# Altium schematic sheet InductorLadder.SchDoc
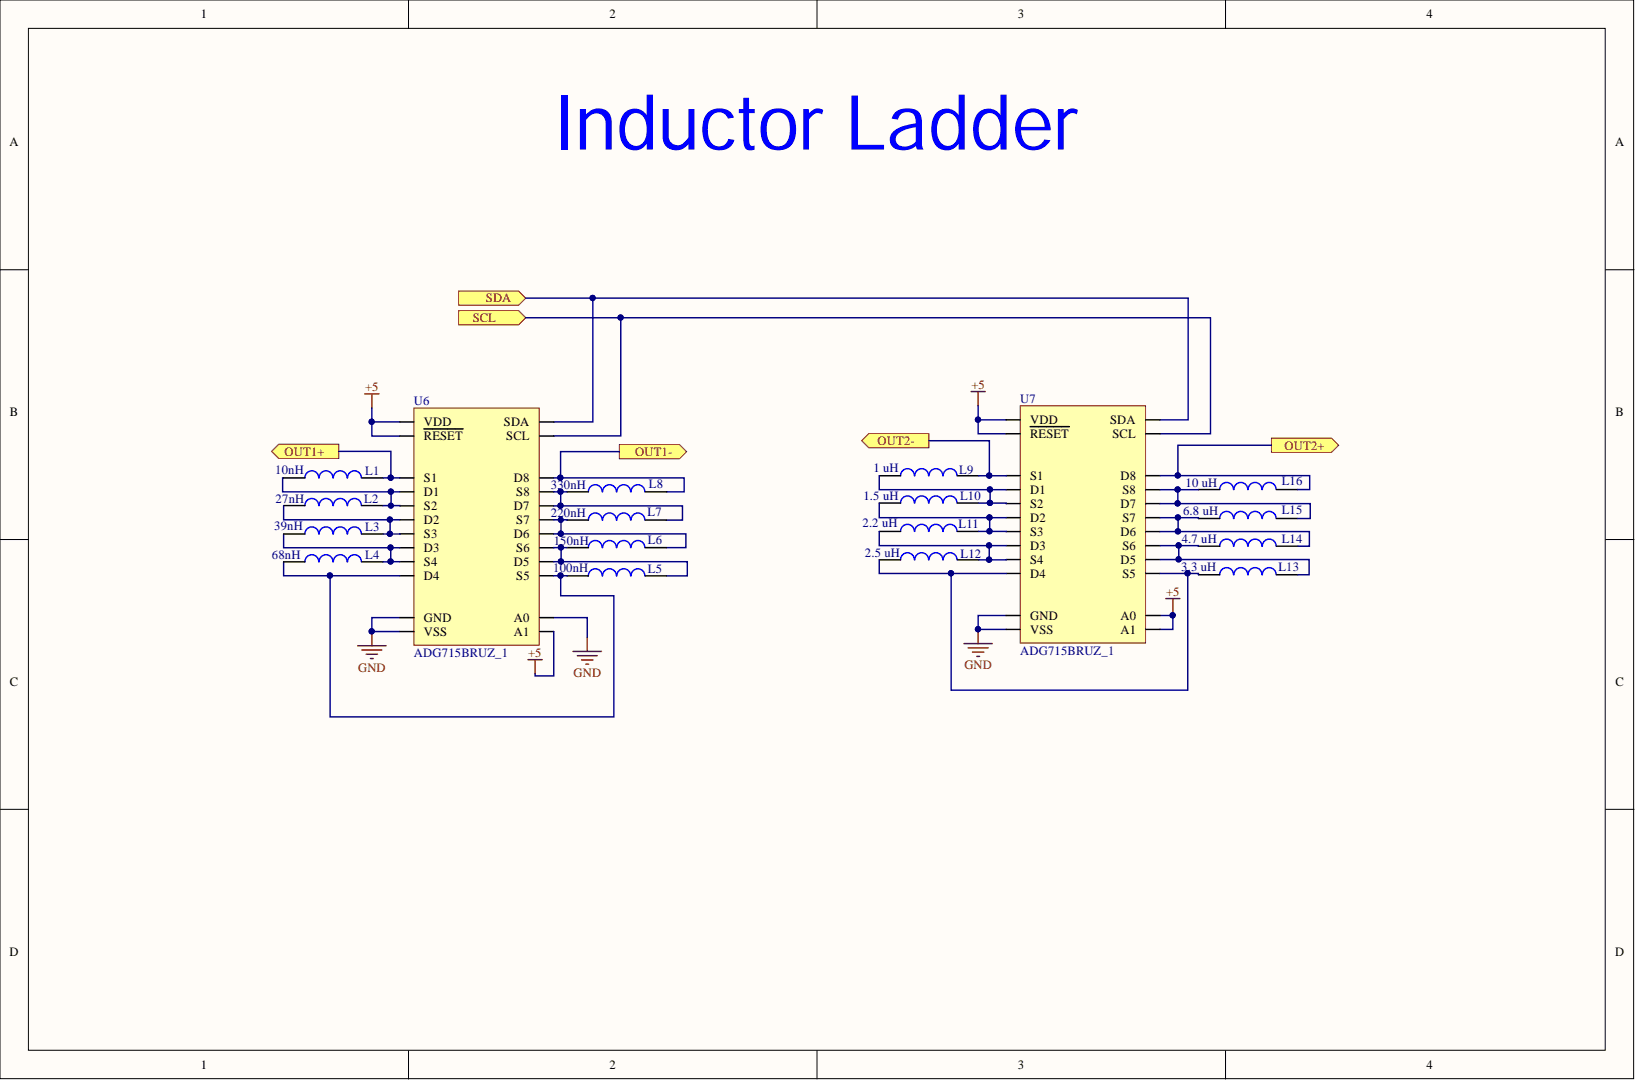
[diagram p0: InductorLadder.SchDoc]

## Parts
L1  value 10nH  [WE-KI_1008A]  (hidden comment: WE-KI, 744762110A)
L2  value 27nH  [WE-KI_1008A]  (hidden comment: WE-KI, 744762127A)
L3  value 39nH  [WE-KI_1008A]  (hidden comment: WE-KI, 744762139A)
L4  value 68nH  [WE-KI_1008A]  (hidden comment: WE-KI, 744762168A)
L5  value 100nH  [WE-KI_1008A]  (hidden comment: WE-KI, 744762210A)
L6  value 150nH  [WE-KI_1008A]  (hidden comment: WE-KI, 744762215A)
L7  value 220nH  [WE-KI_1008A]  (hidden comment: WE-KI, 744762222A)
L8  value 330nH  [WE-KI_1008A]  (hidden comment: WE-KI, 744762233A)
L9  1 uH  [WE-LQS_2010]
L10  1.5 uH  [WE-LQS_2010]
L11  2.2 uH  [WE-LQS_2010]
L12  2.5 uH  [WE-LQS_2010]
L13  3.3 uH  [WE-LQS_2010]
L14  4.7 uH  [WE-LQS_2010]
L15  6.8 uH  [WE-LQS_2010]
L16  10 uH  [WE-LQS_2010]
U6  ADG715BRUZ_1  [ADG715BRUZ]
U7  ADG715BRUZ_1  [ADG715BRUZ]

## Ports
OUT1+, OUT1-, OUT2+, OUT2-, SCL, SDA

## Nets
+5: U6.2(VDD) U6.22(A1) U6.23(RESET) U7.2(VDD) U7.22(A1) U7.23(RESET) U7.24(A0)
GND: U6.4(GND) U6.21(VSS) U6.24(A0) U7.4(GND) U7.21(VSS)
SCL: U6.1(SCL) U7.1(SCL) ; port SCL
SDA: U6.3(SDA) U7.3(SDA) ; port SDA
NetL1_1: L1.1 L2.2 U6.6(D1) U6.7(S2)
NetL1_2: L1.2 U6.5(S1)
NetL2_1: L2.1 L3.2 U6.8(D2) U6.9(S3)
NetL3_1: L3.1 L4.2 U6.10(D3) U6.11(S4)
NetL4_1: L4.1 L5.1 U6.12(D4) U6.14(S5)
NetL5_2: L5.2 L6.1 U6.13(D5) U6.16(S6)
NetL6_2: L6.2 L7.1 U6.15(D6) U6.18(S7)
NetL7_2: L7.2 L8.1 U6.17(D7) U6.20(S8)
NetL8_2: L8.2 U6.19(D8)
NetL9_1: L9.1 L10.2 U7.6(D1) U7.7(S2)
NetL9_2: L9.2 U7.5(S1)
NetL10_1: L10.1 L11.2 U7.8(D2) U7.9(S3)
NetL11_1: L11.1 L12.2 U7.10(D3) U7.11(S4)
NetL12_1: L12.1 L13.1 U7.12(D4) U7.14(S5)
NetL13_2: L13.2 L14.1 U7.13(D5) U7.16(S6)
NetL14_2: L14.2 L15.1 U7.15(D6) U7.18(S7)
NetL15_2: L15.2 L16.1 U7.17(D7) U7.20(S8)
NetL16_2: L16.2 U7.19(D8)

## Library data of the parts
L1 = WE-KI, 744762110A: WE-KI SMD Wire Wound Ceramic Inductor, size 1008A, 10nH; Component Kind: Standard; ComponentLink1Description: Manufacturer; ComponentLink1URL: http://www.we-online.de; ComponentLink2Description: Datasheet; ComponentLink2URL: http://katalog.we-online.de/pbs/datasheet/744762110A.pdf; PartNumber: 744762110A; PublishedDate: Sep. 2016; Size: 1008A; Supplier 1: Digi-Key; Supplier Part Number 1: 732-1808-6-ND; Library Name: DynamicComplexModulo_v1i1.SchLib; Publisher: Wurth Elektronik
L2 = WE-KI, 744762127A: WE-KI SMD Wire Wound Ceramic Inductor, size 1008A, 27nH; Component Kind: Standard; ComponentLink1Description: Manufacturer; ComponentLink1URL: http://www.we-online.de; ComponentLink2Description: Datasheet; ComponentLink2URL: http://katalog.we-online.de/pbs/datasheet/744762127A.pdf; PartNumber: 744762127A; PublishedDate: Sep. 2016; Size: 1008A; Supplier 1: Digi-Key; Supplier Part Number 1: 732-1813-6-ND; Library Name: DynamicComplexModulo_v1i1.SchLib; Publisher: Wurth Elektronik
L3 = WE-KI, 744762139A: WE-KI SMD Wire Wound Ceramic Inductor, size 1008A, 39nH; Component Kind: Standard; ComponentLink1Description: Manufacturer; ComponentLink1URL: http://www.we-online.de; ComponentLink2Description: Datasheet; ComponentLink2URL: http://katalog.we-online.de/pbs/datasheet/744762139A.pdf; PartNumber: 744762139A; PublishedDate: Sep. 2016; Size: 1008A; Supplier 1: Digi-Key; Supplier Part Number 1: 732-1815-6-ND; Library Name: DynamicComplexModulo_v1i1.SchLib; Publisher: Wurth Elektronik
L4 = WE-KI, 744762168A: WE-KI SMD Wire Wound Ceramic Inductor, size 1008A, 68nH; Component Kind: Standard; ComponentLink1Description: Manufacturer; ComponentLink1URL: http://www.we-online.de; ComponentLink2Description: Datasheet; ComponentLink2URL: http://katalog.we-online.de/pbs/datasheet/744762168A.pdf; PartNumber: 744762168A; PublishedDate: Sep. 2016; Size: 1008A; Supplier 1: Digi-Key; Supplier Part Number 1: 732-1818-6-ND; Library Name: DynamicComplexModulo_v1i1.SchLib; Publisher: Wurth Elektronik
L5 = WE-KI, 744762210A: WE-KI SMD Wire Wound Ceramic Inductor, size 1008A, 100nH; Component Kind: Standard; ComponentLink1Description: Manufacturer; ComponentLink1URL: http://www.we-online.de; ComponentLink2Description: Datasheet; ComponentLink2URL: http://katalog.we-online.de/pbs/datasheet/744762210A.pdf; PartNumber: 744762210A; PublishedDate: Sep. 2016; Size: 1008A; Supplier 1: Digi-Key; Supplier Part Number 1: 732-1820-6-ND; Library Name: DynamicComplexModulo_v1i1.SchLib; Publisher: Wurth Elektronik
L6 = WE-KI, 744762215A: WE-KI SMD Wire Wound Ceramic Inductor, size 1008A, 150nH; Component Kind: Standard; ComponentLink1Description: Manufacturer; ComponentLink1URL: http://www.we-online.de; ComponentLink2Description: Datasheet; ComponentLink2URL: http://katalog.we-online.de/pbs/datasheet/744762215A.pdf; PartNumber: 744762215A; PublishedDate: Sep. 2016; Size: 1008A; Supplier 1: Digi-Key; Supplier Part Number 1: 732-1822-6-ND; Library Name: DynamicComplexModulo_v1i1.SchLib; Publisher: Wurth Elektronik
L7 = WE-KI, 744762222A: WE-KI SMD Wire Wound Ceramic Inductor, size 1008A, 220nH; Component Kind: Standard; ComponentLink1Description: Manufacturer; ComponentLink1URL: http://www.we-online.de; ComponentLink2Description: Datasheet; ComponentLink2URL: http://katalog.we-online.de/pbs/datasheet/744762222A.pdf; PartNumber: 744762222A; PublishedDate: Sep. 2016; Size: 1008A; Supplier 1: Digi-Key; Supplier Part Number 1: 732-1824-6-ND; Library Name: DynamicComplexModulo_v1i1.SchLib; Publisher: Wurth Elektronik
L8 = WE-KI, 744762233A: WE-KI SMD Wire Wound Ceramic Inductor, size 1008A, 330nH; Component Kind: Standard; ComponentLink1Description: Manufacturer; ComponentLink1URL: http://www.we-online.de; ComponentLink2Description: Datasheet; ComponentLink2URL: http://katalog.we-online.de/pbs/datasheet/744762233A.pdf; PartNumber: 744762233A; PublishedDate: Sep. 2016; Size: 1008A; Supplier 1: Digi-Key; Supplier Part Number 1: 732-1826-6-ND; Library Name: DynamicComplexModulo_v1i1.SchLib; Publisher: Wurth Elektronik
L9 = 74404020010: WE-LQS SMD Power Inductor, size 2010, 1 uH, 1.49 A; Manufacturer: Wurth Electronics; DC Resistance: 0.095 Ohm; Rated Current max.: 1.49 A; Component Kind: Standard; ComponentLink1Description: Manufacturer; ComponentLink1URL: http://www.we-online.de; ComponentLink2Description: Datasheet; ComponentLink2URL: http://katalog.we-online.de/pbs/datasheet/74404020010.pdf; Inductance: 1 uH; Manufacturer Part Number: 74404020010; Operating Temperature: -40 to +125 degC; Part Number: 74404020010; Pricing 1: 1=0.56, 50=0.4698, 100=0.357, 1000=0.31007 (USD); Published Date: Dec. 2017; Size: 2010; Stock 1: 3959; Supplier 1: Digi-Key; Supplier Part Number 1: 732-10739-6-ND; Library Name: DynamicComplexModulo_v1i1.SchLib; Publisher: Wurth Elektronik
L10 = 74404020015: WE-LQS SMD Power Inductor, size 2010, 1.5 uH, 1.19 A; Manufacturer: Wurth Electronics; DC Resistance: 0.145 Ohm; Rated Current max.: 1.19 A; Component Kind: Standard; ComponentLink1Description: Manufacturer; ComponentLink1URL: http://www.we-online.de; ComponentLink2Description: Datasheet; ComponentLink2URL: http://katalog.we-online.de/pbs/datasheet/74404020015.pdf; Inductance: 1.5 uH; Manufacturer Part Number: 74404020015; Operating Temperature: -40 to +125 degC; Part Number: 74404020015; Pricing 1: 1=0.56, 50=0.4698, 100=0.357, 1000=0.31007 (USD); Published Date: Dec. 2017; Size: 2010; Stock 1: 3931; Supplier 1: Digi-Key; Supplier Part Number 1: 732-10740-6-ND; Library Name: DynamicComplexModulo_v1i1.SchLib; Publisher: Wurth Elektronik
L11 = 74404020022: WE-LQS SMD Power Inductor, size 2010, 2.2 uH, 0.96 A; Manufacturer: Wurth Electronics; DC Resistance: 0.22 Ohm; Rated Current max.: 0.96 A; Component Kind: Standard; ComponentLink1Description: Manufacturer; ComponentLink1URL: http://www.we-online.de; ComponentLink2Description: Datasheet; ComponentLink2URL: http://katalog.we-online.de/pbs/datasheet/74404020022.pdf; Inductance: 2.2 uH; Manufacturer Part Number: 74404020022; Operating Temperature: -40 to +125 degC; Part Number: 74404020022; Pricing 1: 1=0.56, 50=0.4698, 100=0.357, 1000=0.31007 (USD); Published Date: Dec. 2017; Size: 2010; Stock 1: 3236; Supplier 1: Digi-Key; Supplier Part Number 1: 732-10741-6-ND; Library Name: DynamicComplexModulo_v1i1.SchLib; Publisher: Wurth Elektronik
L12 = 74405020022: WE-LQS SMD Power Inductor, size 2010, 2.5 uH, 0.96 A; Manufacturer: Wurth Electronics; Manufacturer Part Number: 74404020022; DC Resistance: 0.22 Ohm; Inductance: 2.2 uH; Rated Current max.: 0.96 A; Component Kind: Standard; ComponentLink1Description: Manufacturer; ComponentLink1URL: http://www.we-online.de; ComponentLink2Description: Datasheet; ComponentLink2URL: http://katalog.we-online.de/pbs/datasheet/74404020022.pdf; Operating Temperature: -40 to +125 degC; Part Number: 74404020022; Pricing 1: 1=0.63, 50=0.522, 100=0.3967, 1000=0.34451 (USD); Published Date: Dec. 2017; Size: 2010; Stock 1: 4000; Supplier 1: Digi-Key; Supplier Part Number 1: 732-10770-6-ND; Library Name: DynamicComplexModulo_v1i1.SchLib; Publisher: Wurth Elektronik
L13 = 74404020033: WE-LQS SMD Power Inductor, size 2010, 3.3 uH, 0.87 A; Manufacturer: Wurth Electronics; DC Resistance: 0.279 Ohm; Rated Current max.: 0.87 A; Component Kind: Standard; ComponentLink1Description: Manufacturer; ComponentLink1URL: http://www.we-online.de; ComponentLink2Description: Datasheet; ComponentLink2URL: http://katalog.we-online.de/pbs/datasheet/74404020033.pdf; Inductance: 3.3 uH; Manufacturer Part Number: 74404020033; Operating Temperature: -40 to +125 degC; Part Number: 74404020033; Pricing 1: 1=0.56, 50=0.4698, 100=0.357, 1000=0.31007 (USD); Published Date: Dec. 2017; Size: 2010; Stock 1: 3787; Supplier 1: Digi-Key; Supplier Part Number 1: 732-10742-6-ND; Library Name: DynamicComplexModulo_v1i1.SchLib; Publisher: Wurth Elektronik
L14 = 74404020047: WE-LQS SMD Power Inductor, size 2010, 4.7 uH, 0.73 A; Manufacturer: Wurth Electronics; DC Resistance: 0.399 Ohm; Rated Current max.: 0.73 A; Component Kind: Standard; ComponentLink1Description: Manufacturer; ComponentLink1URL: http://www.we-online.de; ComponentLink2Description: Datasheet; ComponentLink2URL: http://katalog.we-online.de/pbs/datasheet/74404020047.pdf; Inductance: 4.7 uH; Manufacturer Part Number: 74404020047; Operating Temperature: -40 to +125 degC; Part Number: 74404020047; Pricing 1: 1=0.56, 50=0.4698, 100=0.357, 1000=0.31007 (USD); Published Date: Dec. 2017; Size: 2010; Stock 1: 3398; Supplier 1: Digi-Key; Supplier Part Number 1: 732-10743-6-ND; Library Name: DynamicComplexModulo_v1i1.SchLib; Publisher: Wurth Elektronik
L15 = 74404020068: WE-LQS SMD Power Inductor, size 2010, 6.8 uH, 0.56 A; Manufacturer: Wurth Electronics; DC Resistance: 0.68 Ohm; Rated Current max.: 0.56 A; Component Kind: Standard; ComponentLink1Description: Manufacturer; ComponentLink1URL: http://www.we-online.de; ComponentLink2Description: Datasheet; ComponentLink2URL: http://katalog.we-online.de/pbs/datasheet/74404020068.pdf; Inductance: 6.8 uH; Manufacturer Part Number: 74404020068; Operating Temperature: -40 to +125 degC; Part Number: 74404020068; Pricing 1: 1=0.56, 50=0.4698, 100=0.357, 1000=0.31007 (USD); Published Date: Dec. 2017; Size: 2010; Stock 1: 3558; Supplier 1: Digi-Key; Supplier Part Number 1: 732-10744-6-ND; Library Name: DynamicComplexModulo_v1i1.SchLib; Publisher: Wurth Elektronik
L16 = 74404020100: WE-LQS SMD Power Inductor, size 2010, 10 uH, 0.5 A; Manufacturer: Wurth Electronics; DC Resistance: 0.85 Ohm; Rated Current max.: 0.5 A; Component Kind: Standard; ComponentLink1Description: Manufacturer; ComponentLink1URL: http://www.we-online.de; ComponentLink2Description: Datasheet; ComponentLink2URL: http://katalog.we-online.de/pbs/datasheet/74404020100.pdf; ComponentLink3Description: http://www.farnell.com/datasheets/2277209.pdf; ComponentLink3URL: http://www.farnell.com/datasheets/2277209.pdf; Inductance: 10 uH; Manufacturer Part Number: 74404020100; Operating Temperature: -40 to +125 degC; Part Number: 74404020100; Pricing 1: 1=0.56, 50=0.4698, 100=0.357, 1000=0.31007 (USD); Published Date: Dec. 2017; Size: 2010; Stock 1: 3185; Supplier 1: Digi-Key; Supplier Part Number 1: 732-10745-6-ND; Library Name: DynamicComplexModulo_v1i1.SchLib; Publisher: Wurth Elektronik
U6 U7 = ADG715BRUZ_1: ANALOG DEVICES         ADG715BRUZ            Analogue Switch, Octal Channel, SPST, 8 Channels, 4.5 ohm, 2.7V to 5.5V, TSSOP, 24 Pins; -3db Bandwidth: 155MHz; Drain to Source Resistance: 2.5R; Dual Supply Voltage: 2.5V; High Level Output Current: 30mA; Interface: Serial; Max Dual Supply Voltage: 2.75V; Max Power Dissipation: 125uW; Max Supply Current: 20uA; Min Dual Supply Voltage: 2.25V; Number of Bits: 8; On-State Resistance: 4.5R; Operating Supply Current: 10uA; Supply Type: Single,Dual; Turn-Off Delay Time: 130ns; Turn-On Delay Time: 140ns; Bandwidth: 155MHz; Case/Package: TSSOP; Component Kind: Standard; ComponentLink1Description: https://datasheet.ciiva.com/2978/adg714-715-2978656.pdf; ComponentLink1URL: https://datasheet.ciiva.com/2978/adg714-715-2978656.pdf; ComponentLink2Description: https://datasheet.ciiva.com/1668/adg714-715-1668978.pdf; ComponentLink2URL: https://datasheet.ciiva.com/1668/adg714-715-1668978.pdf; ComponentLink3Description: https://datasheet.ciiva.com/15127/getdatasheetpartid-123058-15127194.pdf; ComponentLink3URL: https://datasheet.ciiva.com/15127/getdatasheetpartid-123058-15127194.pdf; ComponentLink4Description: https://datasheet.ciiva.com/16637/adg714-715-16637994.pdf; ComponentLink4URL: https://datasheet.ciiva.com/16637/adg714-715-16637994.pdf; ComponentLink5Description: https://datasheet.ciiva.com/5797/adg714-715-5797179.pdf; ComponentLink5URL: https://datasheet.ciiva.com/5797/adg714-715-5797179.pdf; ComponentLink6Description: https://datasheet.ciiva.com/48708/001248020-da-01-en-ic-switch-octal-adg715bruz-tssop-24-adi-48708535.pdf; ComponentLink6URL: https://datasheet.ciiva.com/48708/001248020-da-01-en-ic-switch-octal-adg715bruz-tssop-24-adi-48708535.pdf; Contact Plating: Tin,Matte; Height: 1.05mm; Lead Free: Contains Lead; Length: 7.8mm; Manufacturer: Analog Devices; Manufacturer Part Number: ADG715BRUZ; Max Operating Temperature: 85°C; Max Supply Voltage: 5.5V; Min Operating Temperature: -40°C; Min Supply Voltage: 2.7V; Nominal Supply Current: 20uA; Number of Channels: 8; Number of Circuits: 8; Number of Inputs: 8; Number of Outputs: 8; Number of Pins: 24; Package Quantity: 62; Packaging: Rail/Tube; Power Dissipation: 125uW; Pricing 1: 1=6.12, 62=5.19758, 124=4.50452, 558=3.83461, 1054=3.234 (USD); Radiation Hardening: No; REACH SVHC: No SVHC; Stock 1: 3027; Supplier 1: Digi-Key; Supplier Part Number 1: ADG715BRUZ-ND; Throw Configuration: SPST; Width: 4.4mm; Library Name: DynamicComplexModulo_v1i1.SchLib
